# Altium schematic sheet dac.SchDoc
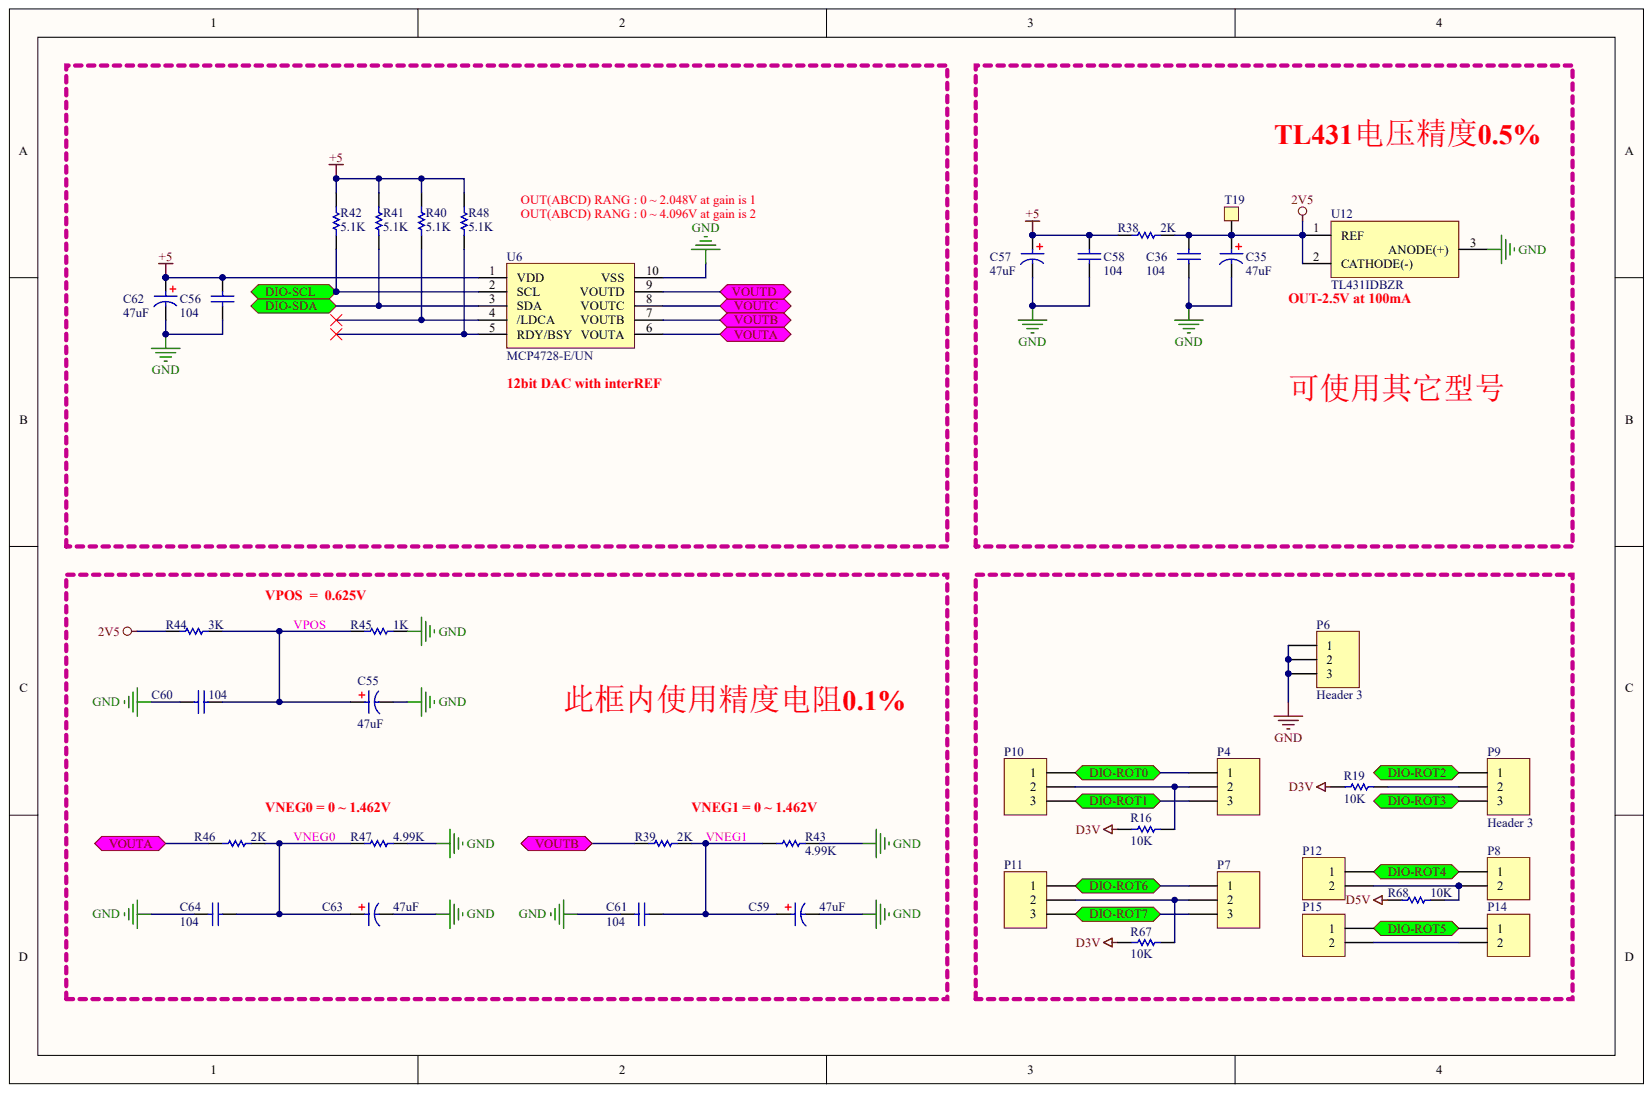
[diagram p0: dac.SchDoc]

## Parts
C35  47uF
C36  C0.1uF  (value not drawn)
C55  47uF
C56  C0.1uF  (value not drawn)
C57  47uF
C58  C0.1uF  (value not drawn)
C59  47uF
C60  C0.1uF  (value not drawn)
C61  C0.1uF  (value not drawn)
C62  47uF
C63  47uF
C64  C0.1uF  (value not drawn)
P4  Header 3  (value not drawn)
P6  Header 3
P7  Header 3  (value not drawn)
P8  Header 2  (value not drawn)
P9  Header 3
P10  Header 3  (value not drawn)
P11  Header 3  (value not drawn)
P12  Header 2  (value not drawn)
P14  Header 2  (value not drawn)
P15  Header 2  (value not drawn)
R16  10K
R19  10K
R38  R2K  (value not drawn)
R39  R2K  (value not drawn)
R40  5.1K
R41  5.1K
R42  5.1K
R43  R4.99K  (value not drawn)
R44  R3K  (value not drawn)
R45  R1K  (value not drawn)
R46  R2K  (value not drawn)
R47  R4.99K  (value not drawn)
R48  5.1K
R67  10K
R68  10K
T19  TP  (value not drawn)
U6  MCP4728-E/UN
U12  TL431IDBZR

## Ports
DIO-ROT0, DIO-ROT1, DIO-ROT2, DIO-ROT3, DIO-ROT4, DIO-ROT5, DIO-ROT6, DIO-ROT7, DIO-SCL, DIO-SDA, VOUTA, VOUTB, VOUTC, VOUTD

## Nets
2V5: C35.1 C36.1 R38.2 R44.2 T19.1 U12.1 U12.2
+5: C56.1 C57.1 C58.1 C62.1 R38.1 R40.2 R41.1 R42.1 R48.1 U6.1(VDD)
D3V: R16.1 R19.1 R67.1
D5V: R68.1
GND: C35.2 C36.2 C55.2 C56.2 C57.2 C58.2 C59.2 C60.1 C61.1 C62.2 C63.2 C64.1 P6.1 P6.2 P6.3 R43.1 R45.1 R47.1 U6.10(VSS) U12.3
DIO-ROT0: P4.1 P10.1 ; port DIO-ROT0
DIO-ROT1: P4.3 P10.3 ; port DIO-ROT1
DIO-ROT2: P9.1 ; port DIO-ROT2
DIO-ROT3: P9.3 ; port DIO-ROT3
DIO-ROT4: P8.1 P12.1 ; port DIO-ROT4
DIO-ROT5: P14.1 P15.1 ; port DIO-ROT5
DIO-ROT6: P7.1 P11.1 ; port DIO-ROT6
DIO-ROT7: P7.3 P11.3 ; port DIO-ROT7
DIO-SCL: R42.2 U6.2(SCL) ; port DIO-SCL
DIO-SDA: R41.2 U6.3(SDA) ; port DIO-SDA
VNEG0: C63.1 C64.2 R46.1 R47.2
VNEG1: C59.1 C61.2 R39.1 R43.2
VOUTA: R46.2 U6.6(VOUTA) ; port VOUTA
VOUTB: R39.2 U6.7(VOUTB) ; port VOUTB
VOUTC: U6.8(VOUTC) ; port VOUTC
VOUTD: U6.9(VOUTD) ; port VOUTD
VPOS: C55.1 C60.2 R44.1 R45.2
NetP4_2: P4.2 P10.2 R16.2
NetP7_2: P7.2 P11.2 R67.2
NetP8_2: P8.2 P12.2 R68.2
NetP9_2: P9.2 R19.2
NetP14_2: P14.2 P15.2
NetR40_1: R40.1 U6.4(/LDCA)
NetR48_2: R48.2 U6.5(RDY/BSY)

## Library data of the parts
C35 C36 C55-C64 P4 P6-P12 P14 P15 R16 R19 R38-R48 R67 R68 T19 U6 U12 = (no symbol name)
  C35 C55 C57 C59 C62 C63: : 47uF
  C36 C56 C58 C60 C61 C64: : 104
  P4 P6-P12 P14 P15: LatestRevisionDate: 17-Jul-2002; LatestRevisionNote: Re-released for DXP Platform.; Publisher: Altium Limited
  R16 R19 R67 R68: : 10K
  R38 R39 R46: : 2K
  R40-R42 R48: : 5.1K
  R43 R47: : 4.99K
  R44: : 3K
  R45: : 1K
